# Altium schematic sheet Motor-Z.SchDoc(Motor-Z)
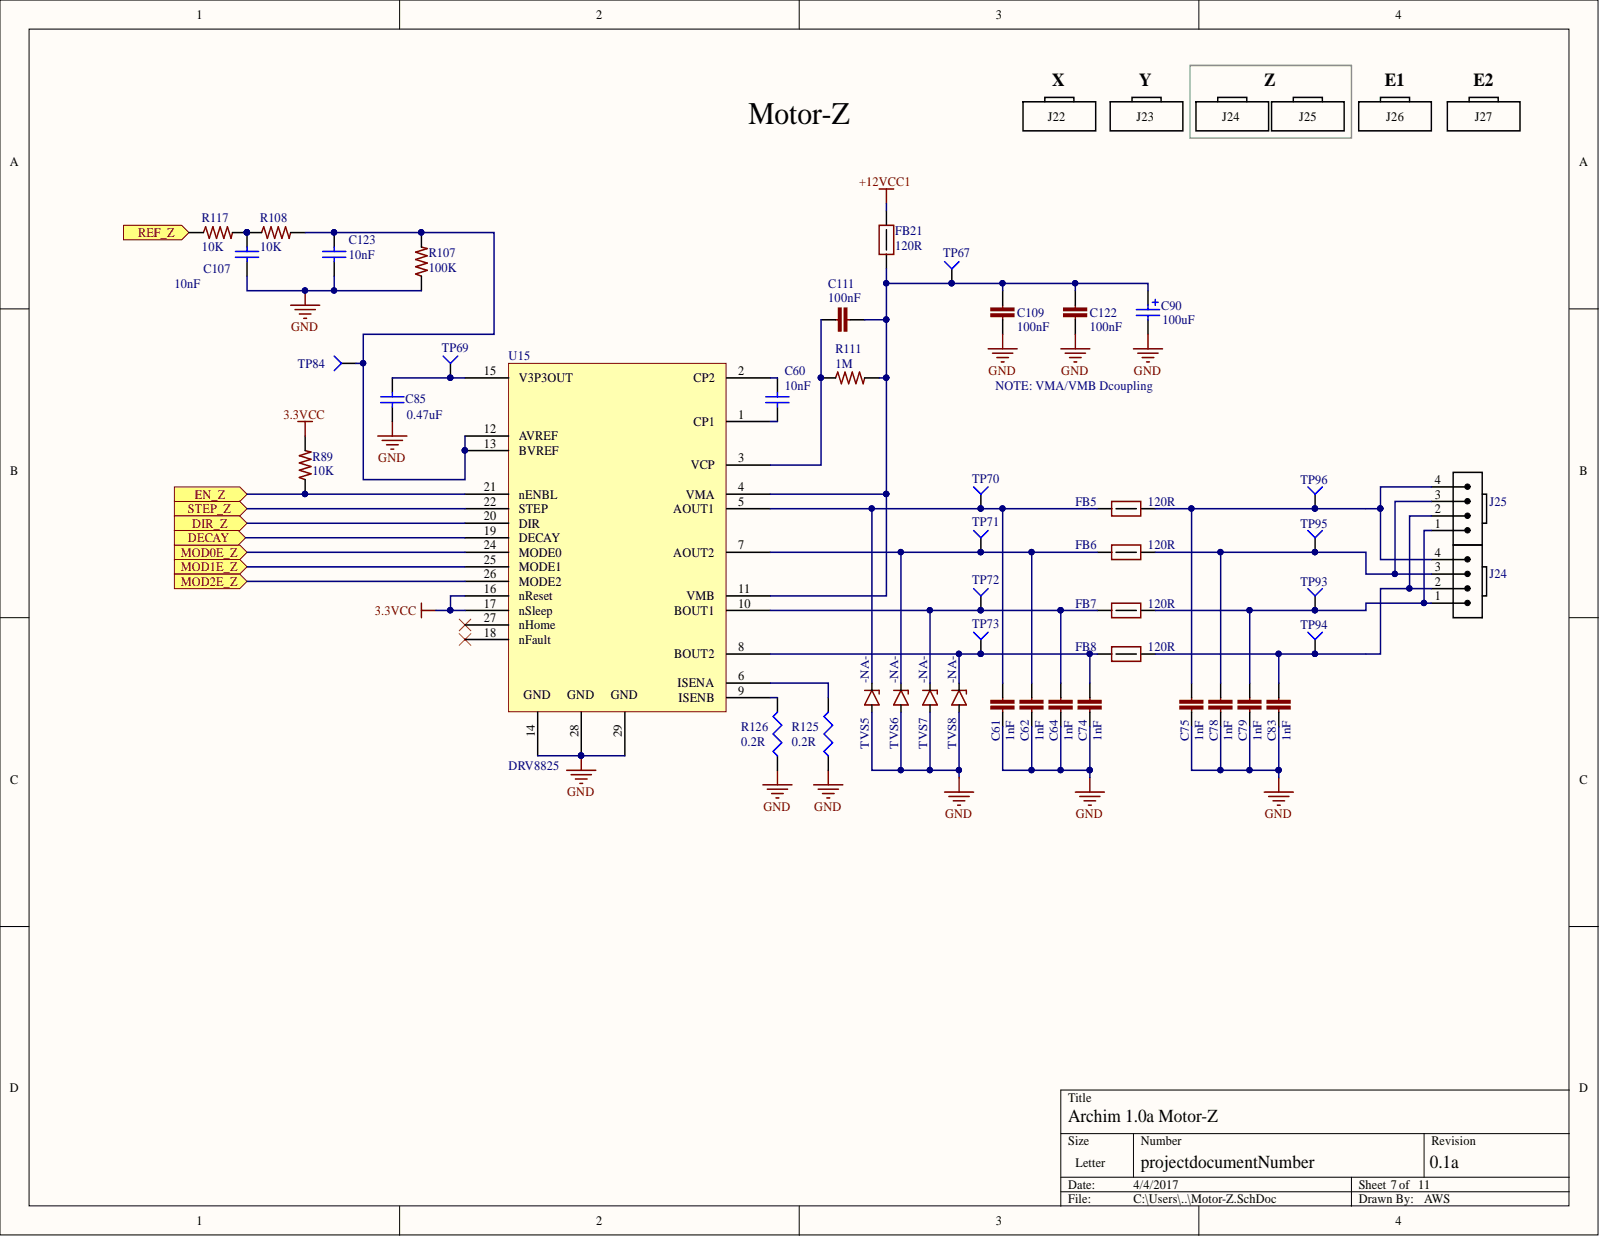
[diagram p0: Motor-Z.SchDoc]

## Parts
C60  10nF  [C0402]
C61  1nF  [C0402]
C62  1nF  [C0402]
C64  1nF  [C0402]
C74  1nF  [C0402]
C75  1nF  [C0402]
C78  1nF  [C0402]
C79  1nF  [C0402]
C83  1nF  [C0402]
C85  value 0.47uF  [C0402]  (hidden comment: 0402)
C90  value 100uF  [CAP-ELEC-063063077]  (hidden comment: UWT1V101MCL1GS)
C107  value 10nF  [C0402]  (hidden comment: 0402)
C109  100nF  [C0603]
C111  100nF  [C0402]
C122  100nF  [C0603]
C123  value 10nF  [C0402]  (hidden comment: 0402)
FB5  120R  [FB0603]
FB6  120R  [FB0603]
FB7  120R  [FB0603]
FB8  120R  [FB0603]
FB21  120R  [FB0603]
J24  Molex 0705430003  [4POL254]  (value not drawn)
J25  Molex 0705430003  [4POL254]  (value not drawn)
R89  10K  [R0402]
R107  100K  [R0402]
R108  10K  [R0402]
R111  1M  [R0402]
R117  10K  [R0402]
R125  value 0.2R  [2512R-0.55]  (hidden comment: 2512)
R126  value 0.2R  [2512R-0.55]  (hidden comment: 2512)
TP67  TP4  [TP-2]  (value not drawn)  (no BOM)
TP69  TP4  [TP-2]  (value not drawn)  (no BOM)
TP70  TP4  [TP-2]  (value not drawn)  (no BOM)
TP71  TP4  [TP-2]  (value not drawn)  (no BOM)
TP72  TP4  [TP-2]  (value not drawn)  (no BOM)
TP73  TP4  [TP-2]  (value not drawn)  (no BOM)
TP84  TP4  [TP-2]  (value not drawn)  (no BOM)
TP93  TP4  [TP-2]  (value not drawn)  (no BOM)
TP94  TP4  [TP-2]  (value not drawn)  (no BOM)
TP95  TP4  [TP-2]  (value not drawn)  (no BOM)
TP96  TP4  [TP-2]  (value not drawn)  (no BOM)
TVS5  value -NA-  [SMF]  (hidden comment: TVS 30V SMF)
TVS6  value -NA-  [SMF]  (hidden comment: TVS 30V SMF)
TVS7  value -NA-  [SMF]  (hidden comment: TVS 30V SMF)
TVS8  value -NA-  [SMF]  (hidden comment: TVS 30V SMF)
U15  DRV8825  [DRV8825PWPR]

## Ports
DECAY, DIR_Z, EN_Z, MOD0E_Z, MOD1E_Z, MOD2E_Z, REF_Z, STEP_Z

## Nets
3.3VCC: R89.2 U15.16(nReset) U15.17(nSleep)
+12VCC1: FB21.2
GND: C61.2 C62.2 C64.2 C74.2 C75.2 C78.2 C79.2 C83.2 C85.1 C90.2 C107.1 C109.2 C122.2 C123.1 R107.1 R125.1 R126.1 TVS5.A TVS6.A TVS7.A TVS8.A U15.14 U15.28 U15.29
DECAY: U15.19(DECAY) ; port DECAY
DIR_Z: U15.20(DIR) ; port DIR_Z
EN_Z: R89.1 U15.21(nENBL) ; port EN_Z
MOD0E_Z: U15.24(MODE0) ; port MOD0E_Z
MOD1E_Z: U15.25(MODE1) ; port MOD1E_Z
MOD2E_Z: U15.26(MODE2) ; port MOD2E_Z
REF_Z: R117.1 ; port REF_Z
STEP_Z: U15.22(STEP) ; port STEP_Z
NetC60_1: C60.1 U15.1(CP1)
NetC60_2: C60.2 U15.2(CP2)
NetC61_1: C61.1 FB5.1 TP70.1 TVS5.K U15.5(AOUT1)
NetC62_1: C62.1 FB6.1 TP71.1 TVS6.K U15.7(AOUT2)
NetC64_1: C64.1 FB7.1 TP72.1 TVS7.K U15.10(BOUT1)
NetC74_1: C74.1 FB8.1 TP73.1 TVS8.K U15.8(BOUT2)
NetC75_1: C75.1 FB5.2 J24.4 J25.4 TP96.1
NetC78_1: C78.1 FB6.2 J24.3 J25.3 TP95.1
NetC79_1: C79.1 FB7.2 J24.1 J25.1 TP93.1
NetC83_1: C83.1 FB8.2 J24.2 J25.2 TP94.1
NetC85_2: C85.2 TP69.1 U15.15(V3P3OUT)
NetC90_1: C90.1 C109.1 C111.2 C122.1 FB21.1 R111.2 TP67.1 U15.4(VMA) U15.11(VMB)
NetC107_2: C107.2 R108.1 R117.2
NetC111_1: C111.1 R111.1 U15.3(VCP)
NetC123_2: C123.2 R107.2 R108.2 TP84.1 U15.12(AVREF) U15.13(BVREF)
NetR125_2: R125.2 U15.6(ISENA)
NetR126_2: R126.2 U15.9(ISENB)

Not connected: U15 18(nFault) 27(nHome)

## Library data of the parts
C60 = GRM155R71H103JA88D: CAP CER 10nF 50V X7R 0402; PART DESCRIPTION: 10000pF ±5% 50V Ceramic Capacitor X7R 0402 (1005 Metric); MANUFACTURER: Murata; TOLERANCE: 5%; VALUE: 10nF; Simulation: CAP; Signal Integrity: Cap Semi; PART: 10nF 50V X7R 0402; MANUFACTURER #: GRM155R71H103JA88D; Library Name: Tigershark3D.SchLib; EE_SPEC: 50V; DISTRIBUTOR #: 490-7763-1-ND; DISTRIBUTOR: Digikey; Component Kind: Standard
C61 C62 C64 C74 C75 C78 C79 C83 = CAP 1nF 50V 0402 X7R: 1000pF 50V Ceramic Capacitor X7R 0402 (1005 Metric) 0.039" L x 0.020" W (1.00mm x 0.50mm); PART DESCRIPTION: 1000pF ±10% 50V Ceramic Capacitor X7R 0402 (1005 Metric); PART: 1nF 50V X7R 0402; MANUFACTURER #: GRM155R71H102KA01D; MANUFACTURER: Murata; TOLERANCE: 10%; VALUE: 1nF; Library Name: 3Delight.SCHLIB; EE_SPEC: 50V; DISTRIBUTOR #: 490-1303-2-ND; DISTRIBUTOR: Digikey; Component Kind: Standard
C85 = GRM155R60J474KE19D: 0.47uF 6.3v C0402 - GRM155R60J474KE19D; PART DESCRIPTION: 0.47µF ±10% 6.3V Ceramic Capacitor X5R 0402 (1005 Metric); PART: 0.47uF 6.3V X5R 0402; MANUFACTURER: Murata; TOLERANCE: 10%; Simulation: CAP; Signal Integrity: Cap Semi; MANUFACTURER #: GRM155R60J474KE19D; Library Name: Tigershark3D.SchLib; EE_SPEC: 6.3V; DISTRIBUTOR #: 490-3266-2-ND; DISTRIBUTOR: Digikey; Component Kind: Standard
C90 = UWT1V101MCL1GS: CAP ALUM 100UF 35V 20% SMD; PART DESCRIPTION: 100µF 35V Aluminum Capacitors Radial, Can - SMD 1000 Hrs @ 105°C; PART: 100uF 35V SMD Al-El; MANUFACTURER: Nichicon; TOLERANCE: 20%; Simulation: CAP; Signal Integrity: Cap Pol; MANUFACTURER #: UWT1V101MCL1GS; Library Name: 3Delight.SCHLIB; EE_SPEC: 35V; DISTRIBUTOR #: 493-2203-2-ND; DISTRIBUTOR: Digikey; Component Kind: Standard
C107 C123 = GRM155R71H103JA88D: CAP CER 10000PF 50V X7R 0402; PART DESCRIPTION: 10000pF ±5% 50V Ceramic Capacitor X7R 0402 (1005 Metric); PART: 10nF 50V X7R 0402; MANUFACTURER: Murata; TOLERANCE: 5%; Simulation: CAP; Signal Integrity: Cap Semi; MANUFACTURER #: GRM155R71H103JA88D; Library Name: Tigershark3D.SchLib; EE_SPEC: 50V; DISTRIBUTOR #: 490-7763-1-ND; DISTRIBUTOR: Digikey; Component Kind: Standard
C109 C122 = C0603C104K5RACTU: CAP CER 0603 100nF 50V 10% X7R; PART DESCRIPTION: 0.10µF ±10% 50V Ceramic Capacitor X7R 0603 (1608 Metric); PART: 100nF 50V X7R 0603; MANUFACTURER: Kemet; VALUE: 100nF; TOLERANCE: 10%; Simulation: Model Name; Signal Integrity: Model Name; MANUFACTURER #: C0603C104K5RACTU; Library Name: 3Delight.SCHLIB; EE_SPEC: 50V; DISTRIBUTOR #: 399-5089-2-ND; DISTRIBUTOR: Digikey; Component Kind: Standard
C111 = GRM155R71C104KA88D: CAP CER 0402 100nF 16V 10% X7R; PART DESCRIPTION: 0.10µF ±10% 16V Ceramic Capacitor X7R 0402 (1005 Metric); PART: 0.1uF 16V X7R 0402; MANUFACTURER: Murata; VALUE: 100nF; TOLERANCE: 10%; Simulation: Model Name; Signal Integrity: Model Name; MANUFACTURER #: GRM155R71C104KA88D; Library Name: 3Delight.SCHLIB; EE_SPEC: 16V; DISTRIBUTOR #: 490-3261-2-ND; DISTRIBUTOR: Digikey; Component Kind: Standard
FB5-FB8 FB21 = FERRITE 120R 3A 0603: FERRITE BEAD 120 OHM 0603 1LN; MANUFACTURER #: CIS10P121AC; MANUFACTURER2#: 490-5254-2-ND; MANUFACTURER: Samsung; VALUE: 120Rfb; TOLERANCE: -NA-; EE_SPEC: 3A; PART DESCRIPTION: FERRITE BEAD 120 OHM 0603 1LN; PART: FERRITE 120R 3A 0603; Library Name: 3Delight.SCHLIB; DISTRIBUTOR #: 1276-6351-2-ND; DISTRIBUTOR: Digikey; Component Kind: Standard
J24 J25 = Molex 0705430003 Alt_1: Molex 4 Pin Clip Connector; PART DESCRIPTION: 4 Positions Header, Shrouded Connector 0.100" (2.54mm) Through Hole Tin; PART: 4 Pin Conn; MANUFACTURER #: 0705430038; VALUE: HDR4; TOLERANCE: -NA-; MANUFACTURER: Molex; EE_SPEC: -NA-; DISTRIBUTOR #: WM4826-ND; DISTRIBUTOR: Digikey; Component Kind: Standard; Library Name: Archim.SCHLIB
R89 R108 R117 = RC0402FR-0710KP: RES SMD 10K OHM 1% 1/16W 0402; PART DESCRIPTION: 10k Ohm ±1% 0.063W, 1/16W Chip Resistor 0402 (1005 Metric) Moisture Resistant Thick Film; PART: 10k 1% 0402; MANUFACTURER: Yageo; VALUE: 10K; TOLERANCE: 1%; Simulation: Model Name; Signal Integrity: Model Name; MANUFACTURER #: RC0402FR-0710KP; Library Name: 3Delight.SCHLIB; EE_SPEC: 1/16W; DISTRIBUTOR #: YAG1249TR-ND; DISTRIBUTOR: Digikey; Component Kind: Standard
R107 = RC1005F104CS: RES SMD 100K OHM 1% 1/16W 0402; PART DESCRIPTION: 100k Ohm ±1% 0.063W, 1/16W Chip Resistor 0402 (1005 Metric) Moisture Resistant Thick Film; PART: 100k 1% 0402; MANUFACTURER: Samsung; VALUE: 100K; TOLERANCE: 1%; Simulation: Model Name; Signal Integrity: Model Name; MANUFACTURER #: RC1005F104CS; Library Name: Tigershark3D.SchLib; EE_SPEC: 1/16W; DISTRIBUTOR #: 1276-3432-1-ND; DISTRIBUTOR: Digikey; Component Kind: Standard
R111 = ERJ2GEJ105X: RES 0402 1M 100mW 5%; PART DESCRIPTION: 1M Ohm ±5% 0.1W, 1/10W Chip Resistor 0402 (1005 Metric) Automotive AEC-Q200 Thick Film; PART: 1M 5% 0402; MANUFACTURER: Panasonic; EE_SPEC: 1/10W; VALUE: 1M; TOLERANCE: 5%; Simulation: Model Name; Signal Integrity: Model Name; MANUFACTURER #: ERJ2GEJ105X; Library Name: 3Delight.SCHLIB; DISTRIBUTOR #: P1.0MJTR-ND; DISTRIBUTOR: Digikey; Component Kind: Standard
R125 R126 = CSRN2512FTR200: RES SMD 0.2 OHM 1% 2W 2512; PART DESCRIPTION: 0.2 Ohm ±1% 2W Chip Resistor 2512 (6432 Metric) Current Sense Thick Film; PART: 0.2R 1% 2512; MANUFACTURER #: CSRN2512FKR200; MANUFACTURER: Stackpole ELectronics Inc; TOLERANCE: 1%; Simulation: RESISTOR; Signal Integrity: Res; Library Name: Tigershark3D.SchLib; EE_SPEC: 2W; DISTRIBUTOR #: CSRN2512FKR200TR-ND; DISTRIBUTOR: Digikey; Component Kind: Standard
TP67 TP69-TP73 TP84 TP93-TP96 = Socket: MANUFACTURER #: NOTAPART-Testpoint; Signal Integrity: Connector; Description: Socket; Library Name: Archim.SCHLIB
TVS5-TVS8 = TVS 30V SMF: TVS DIODE 30VWM 48.4VC SMF; PART: TVS Diode 48.4VC; MANUFACTURER #: SMF30A-E3-08; MANUFACTURER: Vishay; EE_SPEC: 30Vrs 48.4Vc; TOLERANCE: -NA-; PART DESCRIPTION: TVS DIODE 30VWM 48.4VC SMF; Library Name: 3Delight.SCHLIB; DISTRIBUTOR #: SMF30A-E3-08GITR-ND; DISTRIBUTOR: Digikey; Component Kind: Standard
U15 = DRV8825: DRV8825 Ti Stepper Driver; PART DESCRIPTION: Bipolar Motor Driver Power MOSFET Step/Direction 28-HTSSOP; MANUFACTURER: Texas Instruments; VALUE: -NA-; EE_SPEC: 8.2-45VDC; TOLERANCE: -NA-; PART: DRV8825; MANUFACTURER #: DRV8825PWPR; Library Name: Tigershark3D.SchLib; DISTRIBUTOR #: 296-29503-2-ND; DISTRIBUTOR: Digikey; Component Kind: Standard
